# Altium schematic sheet 1_LED_KEY.SchDoc(1_LED_KEY)
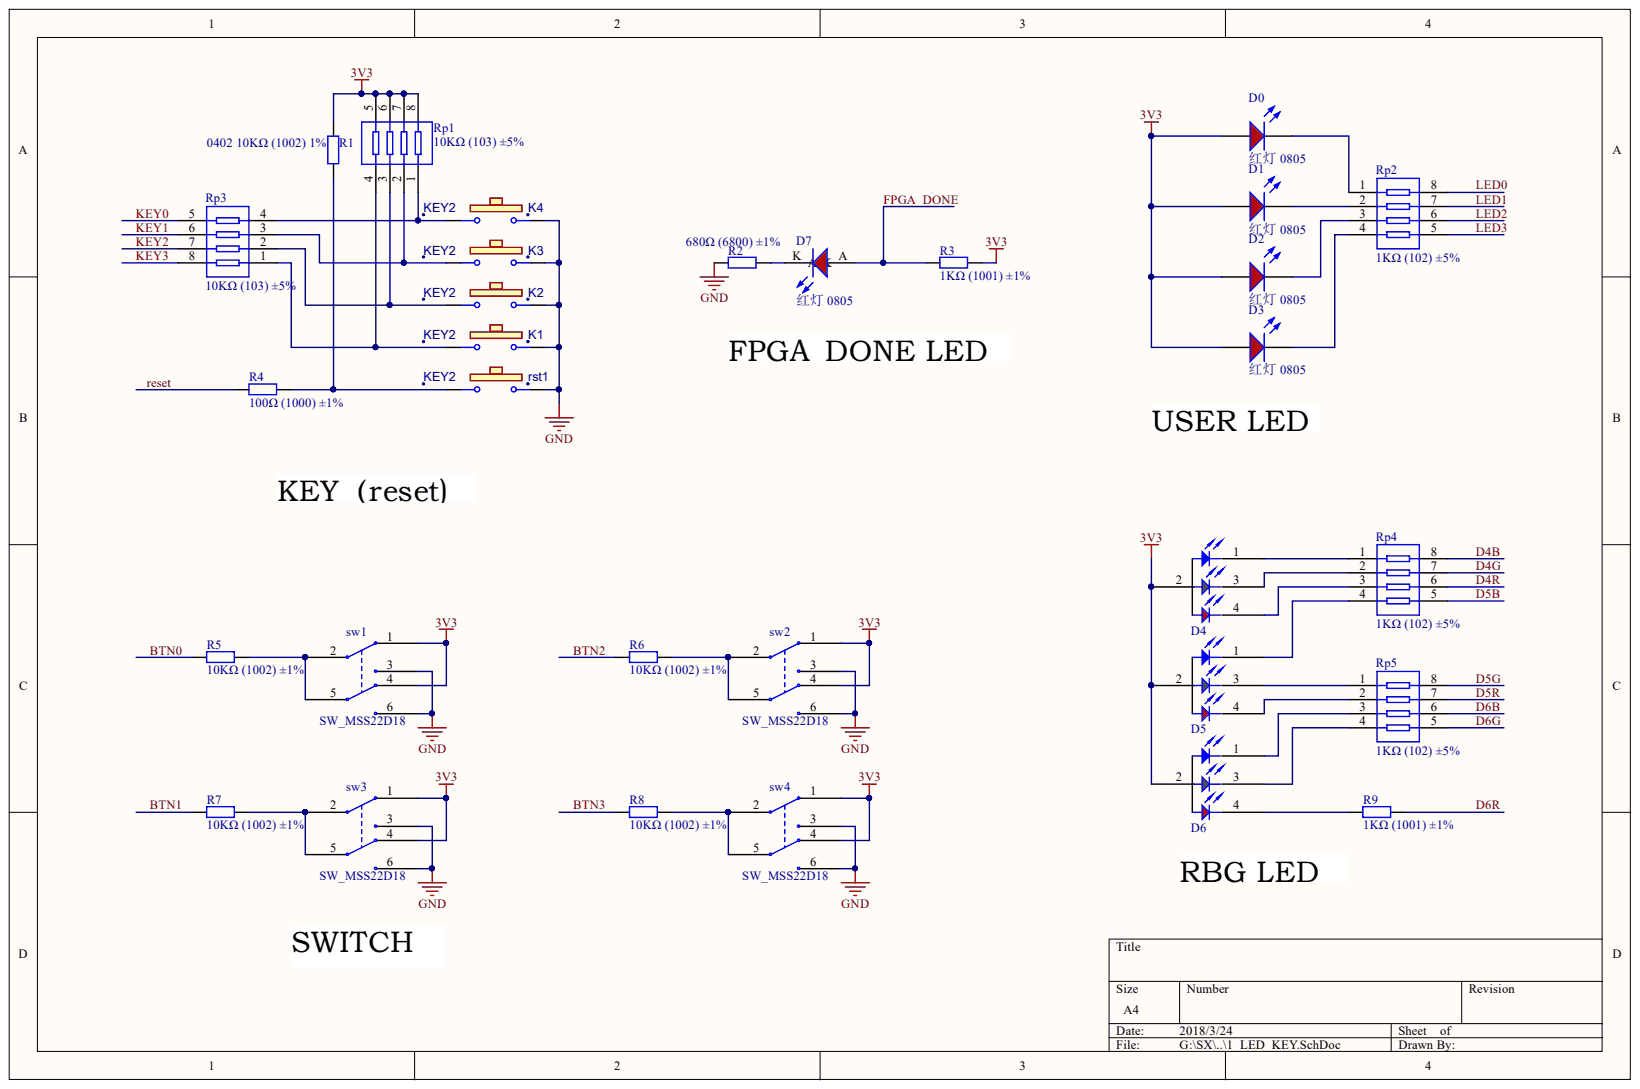
[diagram p0: 1_LED_KEY.SchDoc]

## Parts
D0  红灯 0805  Package: 0805  [0805_LED_S1]
D1  红灯 0805  Package: 0805  [0805_LED_S1]
D2  红灯 0805  Package: 0805  [0805_LED_S1]
D3  红灯 0805  Package: 0805  [0805_LED_S1]
D4  power  [LED_RGB_3528]  (value not drawn)
D5  power  [LED_RGB_3528]  (value not drawn)
D6  power  [LED_RGB_3528]  (value not drawn)
D7  红灯 0805  Package: 0805  [0805_LED_S1]
K1  KEY2  [TSW SMD-3*6*2.5]
K2  KEY2  [TSW SMD-3*6*2.5]
K3  KEY2  [TSW SMD-3*6*2.5]
K4  KEY2  [TSW SMD-3*6*2.5]
R1  0402 10KΩ (1002) 1%  [0402_R]
R2  680Ω (6800) ±1%  [0402_R]
R3  1KΩ (1001) ±1%  [0402_R]
R4  100Ω (1000) ±1%  [0402_R]
R5  10KΩ (1002) ±1%  [0402_R]
R6  10KΩ (1002) ±1%  [0402_R]
R7  10KΩ (1002) ±1%  [0402_R]
R8  10KΩ (1002) ±1%  [0402_R]
R9  1KΩ (1001) ±1%  [0402_R]
Rp1  10KΩ (103) ±5%  [0402_Rx4]
Rp2  1KΩ (102) ±5%  [0402_Rx4]
Rp3  10KΩ (103) ±5%  [0402_Rx4]
Rp4  1KΩ (102) ±5%  [0402_Rx4]
Rp5  1KΩ (102) ±5%  [0402_Rx4]
rst1  KEY2  [TSW SMD-3*6*2.5]
sw1  SW_MSS22D18  [SW_MSS22D18]
sw2  SW_MSS22D18  [SW_MSS22D18]
sw3  SW_MSS22D18  [SW_MSS22D18]
sw4  SW_MSS22D18  [SW_MSS22D18]

## Nets
3V3: D0.A D1.A D2.A D3.A D4.2 D5.2 D6.2 R1.2 R3.2 Rp1.5 Rp1.6 Rp1.7 Rp1.8 sw1.1 sw1.4 sw2.1 sw2.4 sw3.1 sw3.4 sw4.1 sw4.4
GND: K1.2 K2.2 K3.2 K4.2 R2.1 rst1.2 sw1.3 sw1.6 sw2.3 sw2.6 sw3.3 sw3.6 sw4.3 sw4.6
BTN0: R5.2
BTN1: R7.2
BTN2: R6.2
BTN3: R8.2
D4B: Rp4.8
D4G: Rp4.7
D4R: Rp4.6
D5B: Rp4.5
D5G: Rp5.8
D5R: Rp5.7
D6B: Rp5.6
D6G: Rp5.5
D6R: R9.2
FPGA_DONE: D7.A R3.1
KEY0: Rp3.5
KEY1: Rp3.6
KEY2: Rp3.7
KEY3: Rp3.8
LED0: Rp2.8
LED1: Rp2.7
LED2: Rp2.6
LED3: Rp2.5
RESET: R4.1
NetD0_K: D0.K Rp2.1
NetD1_K: D1.K Rp2.2
NetD2_K: D2.K Rp2.3
NetD3_K: D3.K Rp2.4
NetD4_1: D4.1 Rp4.1
NetD4_3: D4.3 Rp4.2
NetD4_4: D4.4 Rp4.3
NetD5_1: D5.1 Rp4.4
NetD5_3: D5.3 Rp5.1
NetD5_4: D5.4 Rp5.2
NetD6_1: D6.1 Rp5.3
NetD6_3: D6.3 Rp5.4
NetD6_4: D6.4 R9.1
NetD7_K: D7.K R2.2
NetK1_1: K1.1 Rp1.4 Rp3.1
NetK2_1: K2.1 Rp1.3 Rp3.2
NetK3_1: K3.1 Rp1.2 Rp3.3
NetK4_1: K4.1 Rp1.1 Rp3.4
NetR1_1: R1.1 R4.2 rst1.1
NetR5_1: R5.1 sw1.2 sw1.5
NetR6_1: R6.1 sw2.2 sw2.5
NetR7_1: R7.1 sw3.2 sw3.5
NetR8_1: R8.1 sw4.2 sw4.5

## Library data of the parts
D0-D3 D7 = 0805 红灯: 发光二极管; Component Kind: Standard; ComponentLink1Description: 供应商链接; ComponentLink1URL: http://www.szlcsc.com/product/details_2668.html; Library Name: Miscellaneous Devices LC.IntLib; Supplier: LC; SuppliersPartNumber: C2295
D4-D6 = LED_RGB: 三色发光二极管3528; Component Kind: Standard; Library Name: Personal_Accumulation_Sch.SchLib
K1-K4 rst1 = KEYB: 两脚轻触按键3*6*2.5mm; Component Kind: Standard; Library Name: AX301_AX4010.IntLib
R1 R5-R8 = 0402 10KΩ (1002) 1%: 贴片电阻; Component Kind: Standard; ComponentLink1Description: 供应商链接; ComponentLink1URL: http://www.szlcsc.com/product/details_26487.html; Library Name: Miscellaneous Devices LC.IntLib; Package: 0402; Supplier: LC; SuppliersPartNumber: C25744
R2 = 0402 680Ω (6800) 1%: 贴片电阻; Component Kind: Standard; ComponentLink1Description: 供应商链接; ComponentLink1URL: http://www.szlcsc.com/product/details_25873.html; Library Name: Miscellaneous Devices LC.IntLib; Package: 0402; Supplier: LC; SuppliersPartNumber: C25130
R3 R9 = 0402 1KΩ (1001) 1%: 贴片电阻; Component Kind: Standard; ComponentLink1Description: 供应商链接; ComponentLink1URL: http://www.szlcsc.com/product/details_12256.html; Library Name: Miscellaneous Devices LC.IntLib; Package: 0402; Supplier: LC; SuppliersPartNumber: C11702
R4 = 0402 100Ω (1000) 1%: 贴片电阻; Component Kind: Standard; ComponentLink1Description: 供应商链接; ComponentLink1URL: http://www.szlcsc.com/product/details_25819.html; Library Name: Miscellaneous Devices LC.IntLib; Package: 0402; Supplier: LC; SuppliersPartNumber: C25076
Rp1 Rp3 = 0402*4 10KΩ (103) 5%: 贴片排阻; Component Kind: Standard; ComponentLink1Description: 供应商链接; ComponentLink1URL: http://www.szlcsc.com/product/details_26468.html; Library Name: Miscellaneous Devices LC.IntLib; Package: 0402*4; Supplier: LC; SuppliersPartNumber: C25725
Rp2 Rp4 Rp5 = 0402*4 1KΩ (102) 5%: 贴片排阻; Component Kind: Standard; ComponentLink1Description: 供应商链接; ComponentLink1URL: http://www.szlcsc.com/product/details_26469.html; Library Name: Miscellaneous Devices LC.IntLib; Package: 0402*4; Supplier: LC; SuppliersPartNumber: C25726
sw1-sw4 = SW_MSS22D18: 贴片拨动开关 6脚2档2mm; Component Kind: Standard; Library Name: Personal_Accumulation_Sch.SchLib
